# Altium schematic sheet ESP32_C3_Calender_v1_00.SchDoc
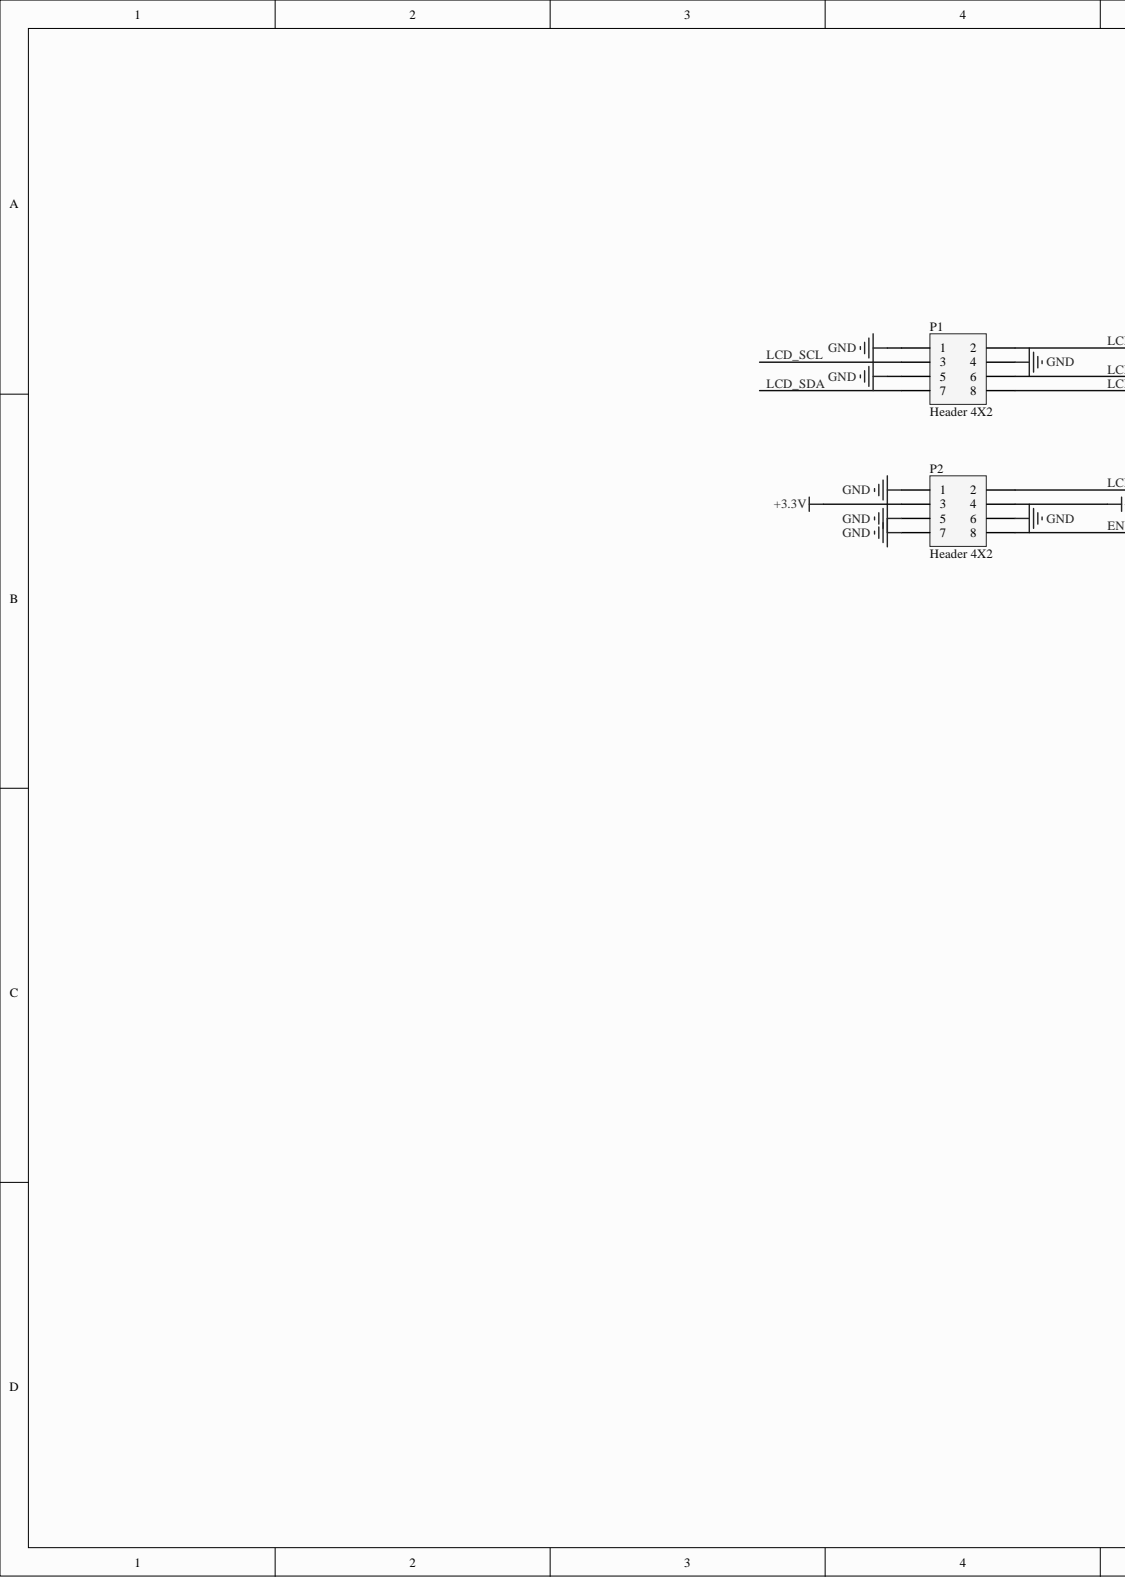
[diagram p0: ESP32_C3_Calender_v1_00.SchDoc, left]
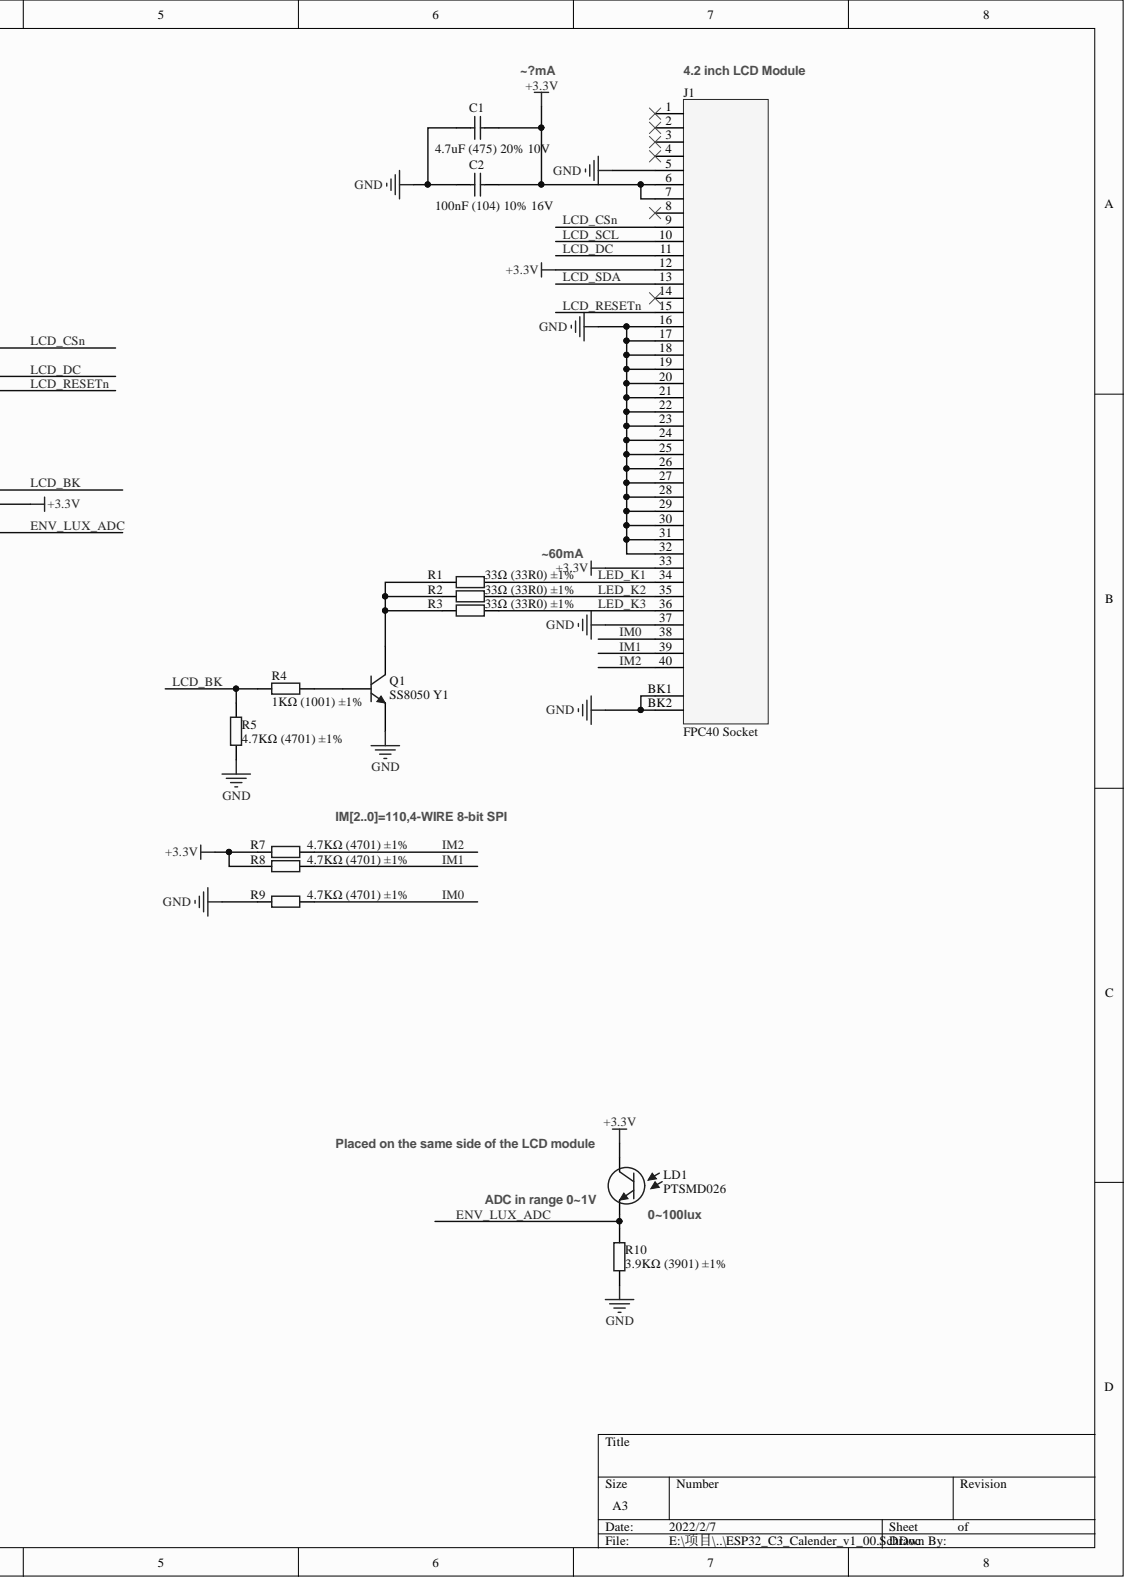
[diagram p1: ESP32_C3_Calender_v1_00.SchDoc, right]

## Parts
C1  4.7uF (475) 20% 10V  [LC-0402_C]
C2  100nF (104) 10% 16V  [LC-0402_C]
J1  FPC40 Socket  [FPC40-P0.5-2]
LD1  PTSMD026  [LC-1206_DIODE]
P1  Header 4X2  [HDR2X4-P2-SMD]
P2  Header 4X2  [HDR2X4-P2-SMD]
Q1  SS8050 Y1  [LC-SOT-23(SOT-23-3)]
R1  33Ω (33R0) ±1%  [LC-0603_R]
R2  33Ω (33R0) ±1%  [LC-0603_R]
R3  33Ω (33R0) ±1%  [LC-0603_R]
R4  1KΩ (1001) ±1%  [LC-0402_R]
R5  4.7KΩ (4701) ±1%  [LC-0402_R]
R7  4.7KΩ (4701) ±1%  [LC-0402_R]
R8  4.7KΩ (4701) ±1%  [LC-0402_R]
R9  4.7KΩ (4701) ±1%  [LC-0402_R]
R10  3.9KΩ (3901) ±1%  [LC-0603_R]

## Nets
+3.3V: C1.2 C2.2 J1.6 J1.7 J1.12 J1.33 LD1.2 P2.3 P2.4 R7.2 R8.2
GND: C1.1 C2.1 J1.5 J1.16 J1.17 J1.18 J1.19 J1.20 J1.21 J1.22 J1.23 J1.24 J1.25 J1.26 J1.27 J1.28 J1.29 J1.30 J1.31 J1.32 J1.37 J1.BK1 J1.BK2 P1.1 P1.4 P1.5 P2.1 P2.5 P2.6 P2.7 Q1.2 R5.1 R9.2 R10.1
ENV_LUX_ADC: LD1.1 P2.8 R10.2
IM0: J1.38 R9.1
IM1: J1.39 R8.1
IM2: J1.40 R7.1
LCD_BK: P2.2 R4.1 R5.2
LCD_CSn: J1.9 P1.2
LCD_DC: J1.11 P1.6
LCD_RESETn: J1.15 P1.8
LCD_SCL: J1.10 P1.3
LCD_SDA: J1.13 P1.7
LED_K1: J1.34 R1.2
LED_K2: J1.35 R2.2
LED_K3: J1.36 R3.2
NetQ1_1: Q1.1 R4.2
NetQ1_3: Q1.3 R1.1 R2.1 R3.1

Not connected: J1 1 2 3 4 8 14

## Library data of the parts
C1 = 0402 4.7uF (475) 20% 10V: 贴片电容; Component Kind: Standard; ComponentLink1Description: 供应商链接; ComponentLink1URL: http://www.szlcsc.com/product/details_24469.html; Library Name: Miscellaneous Devices LC.IntLib; Package: 0402; Supplier: LC; SuppliersPartNumber: C23733
C2 = 0402 100nF (104) 10% 16V: 贴片电容; Component Kind: Standard; ComponentLink1Description: 供应商链接; ComponentLink1URL: http://www.szlcsc.com/product/details_1877.html; Library Name: Miscellaneous Devices LC.IntLib; Package: 0402; Supplier: LC; SuppliersPartNumber: C1525
J1 = FPC40: Component Kind: Standard; Description: FPC40 Socket; Library Name: SCH-20070319.SCHLIB
LD1 = OPTTRANS: NPN General Purpose Amplifier; Component Kind: Standard; Library Name: SCH-20070319.SCHLIB
P1 P2 = Header 4X2: Header, 4-Pin, Dual row; Component Kind: Standard; LatestRevisionDate: 17-Jul-2002; LatestRevisionNote: Re-released for DXP Platform.; Library Name: Miscellaneous Connectors.IntLib; Signal Integrity: Connector; Publisher: Altium Limited
Q1 = SOT-23 SS8050 Y1: 三极管; Component Kind: Standard; ComponentLink1Description: 供应商链接; ComponentLink1URL: http://www.szlcsc.com/product/details_2507.html; Library Name: Miscellaneous Devices LC.IntLib; Package: SOT-23; Supplier: LC; SuppliersPartNumber: C2150
R1-R3 = 0603 33Ω (33R0) 1%: 贴片电阻; Component Kind: Standard; ComponentLink1Description: 供应商链接; ComponentLink1URL: http://www.szlcsc.com/product/details_23867.html; Library Name: Miscellaneous Devices LC.IntLib; Package: 0603; Supplier: LC; SuppliersPartNumber: C23140
R4 = 0402 1KΩ (1001) 1%: 贴片电阻; Component Kind: Standard; ComponentLink1Description: 供应商链接; ComponentLink1URL: http://www.szlcsc.com/product/details_12256.html; Library Name: Miscellaneous Devices LC.IntLib; Package: 0402; Supplier: LC; SuppliersPartNumber: C11702
R5 R7-R9 = 0402 4.7KΩ (4701) 1%: 贴片电阻; Component Kind: Standard; ComponentLink1Description: 供应商链接; ComponentLink1URL: http://www.szlcsc.com/product/details_26643.html; Library Name: Miscellaneous Devices LC.IntLib; Package: 0402; Supplier: LC; SuppliersPartNumber: C25900
R10 = 0603 3.9KΩ (3901) 1%: 贴片电阻; Component Kind: Standard; ComponentLink1Description: 供应商链接; ComponentLink1URL: http://www.szlcsc.com/product/details_23745.html; Library Name: Miscellaneous Devices LC.IntLib; Package: 0603; Supplier: LC; SuppliersPartNumber: C23018
